# Altium schematic sheet SCH.SchDoc(SCH)
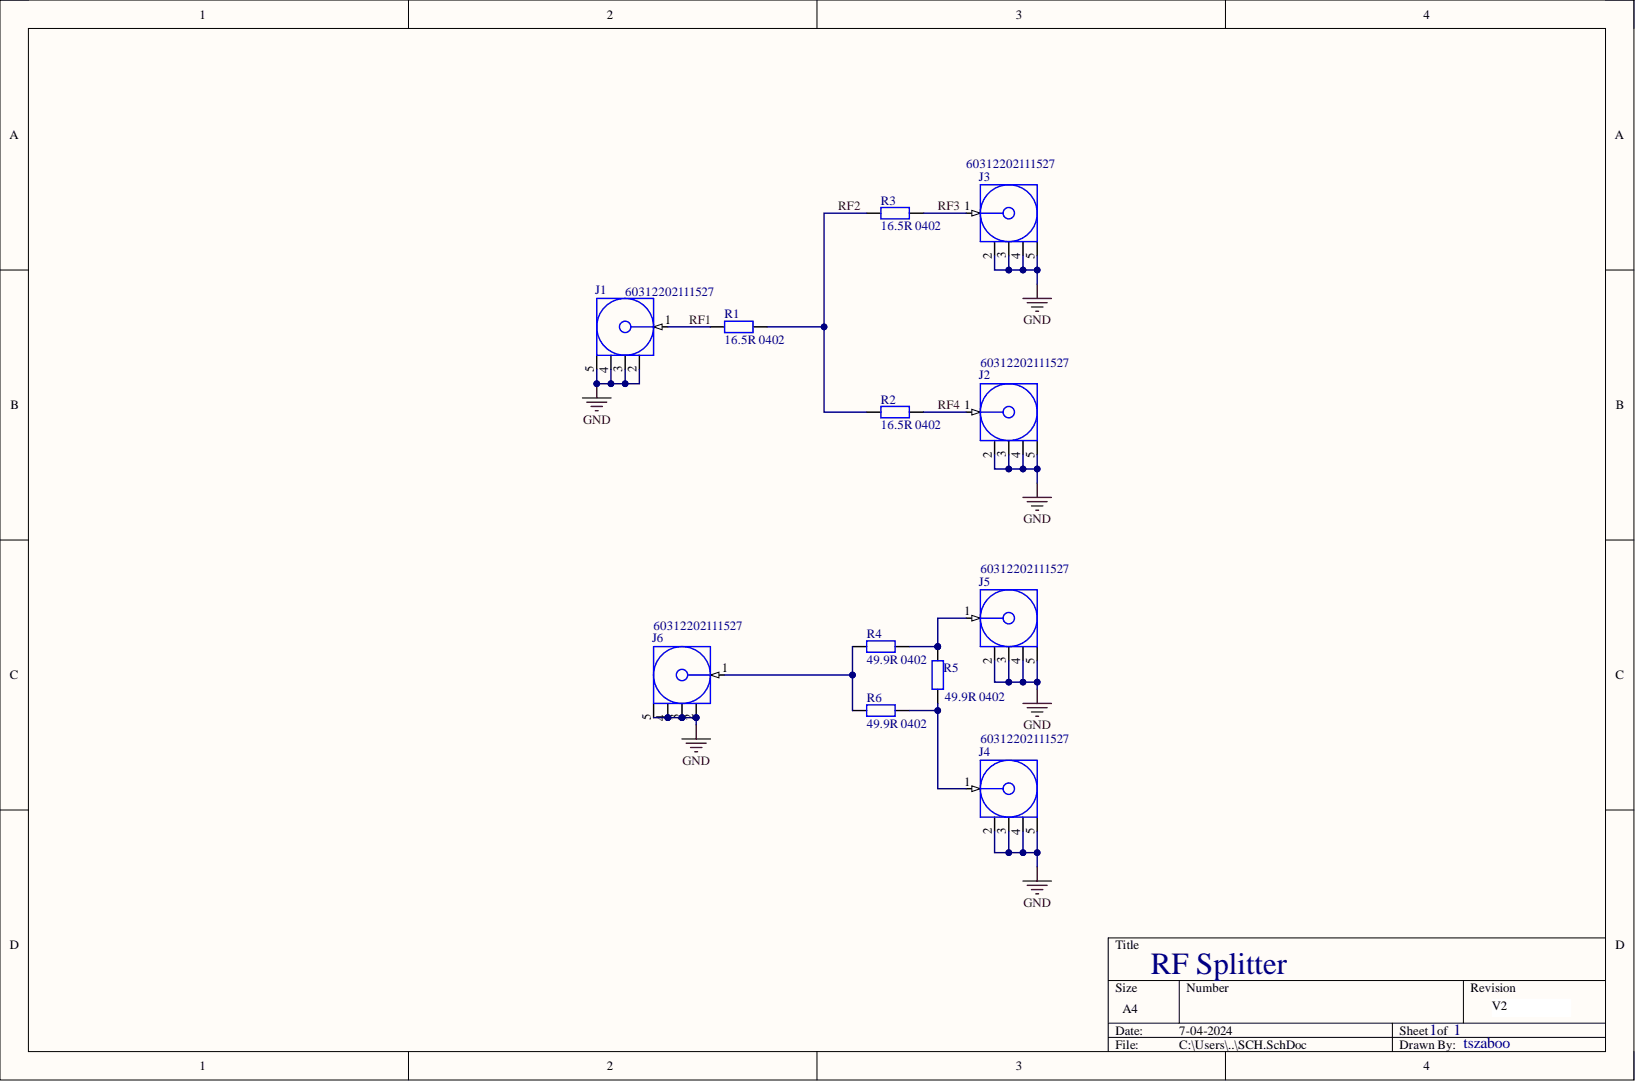
[diagram p0: SCH.SchDoc]

## Parts
J1  60312202111527  [60312202111527]
J2  60312202111527  [60312202111527]
J3  60312202111527  [60312202111527]
J4  60312202111527  [60312202111527]
J5  60312202111527  [60312202111527]
J6  60312202111527  [60312202111527]
R1  value 16.5R  SCH: 16.5R 0402  [RESC0402N]  (hidden comment: Res)
R2  value 16.5R  SCH: 16.5R 0402  [RESC0402N]  (hidden comment: Res)
R3  value 16.5R  SCH: 16.5R 0402  [RESC0402N]  (hidden comment: Res)
R4  value 49.9R  SCH: 49.9R 0402  [RESC0402N]  (hidden comment: Res)
R5  value 49.9R  SCH: 49.9R 0402  [RESC0402N]  (hidden comment: Res)
R6  value 49.9R  SCH: 49.9R 0402  [RESC0402N]  (hidden comment: Res)

## Nets
GND: J1.2 J1.3 J1.4 J1.5 J2.2 J2.3 J2.4 J2.5 J3.2 J3.3 J3.4 J3.5 J4.2 J4.3 J4.4 J4.5 J5.2 J5.3 J5.4 J5.5 J6.2 J6.3 J6.4 J6.5
RF1: J1.1 R1.1
RF2: R1.2 R2.1 R3.1
RF3: J3.1 R3.2
RF4: J2.1 R2.2
NetJ4_1: J4.1 R5.1 R6.2
NetJ5_1: J5.1 R4.2 R5.2
NetJ6_1: J6.1 R4.1 R6.1

## Library data of the parts
J1-J6 = CMP-03913-134708250-1: 60312202111527 WR-SMA_SMA PCB End Launch Jack; Connector Type: SMA; Impedance: 50Ω; Insertion Loss (dB): 0.14dB; Insulation Resistance: 5GΩ; Component Kind: Standard; Insulation Material: PTFE; Library Name: Altium Content Vault; Max Operating Temperature: 165°C; Min Operating Temperature: -65°C; NexarPartId: 134708250; RoHS: Compliant
R1-R3 = CRCW040216R5FKED: RES SMD 16.5 OHM 1% 1/16W 100PPM 0402; Manufacturer: VISHAY; COMPATIBLES: F1; Database Table Name: CRCW0402$; NoPins: 2; Component Kind: Standard; Library Name: VISHAY CRCW.Dblib; Manufacturer P/N: CRCW040216R5FKED; SMD: True; Supplier 1: Digi-Key; Supplier Part Number 1: 541-16.5LCT-ND
R4-R6 = CRCW040249R9FKED: RES SMD 49.9 OHM 1% 1/16W 100PPM 0402; Manufacturer: VISHAY; COMPATIBLES: F1; Database Table Name: CRCW0402$; NoPins: 2; Component Kind: Standard; Library Name: VISHAY CRCW.Dblib; Manufacturer P/N: CRCW040249R9FKED; SMD: True; Supplier 1: Digi-Key; Supplier Part Number 1: 541-49.9LCT-ND
